# Altium schematic sheet 1.Power.SchDoc(1.Power)
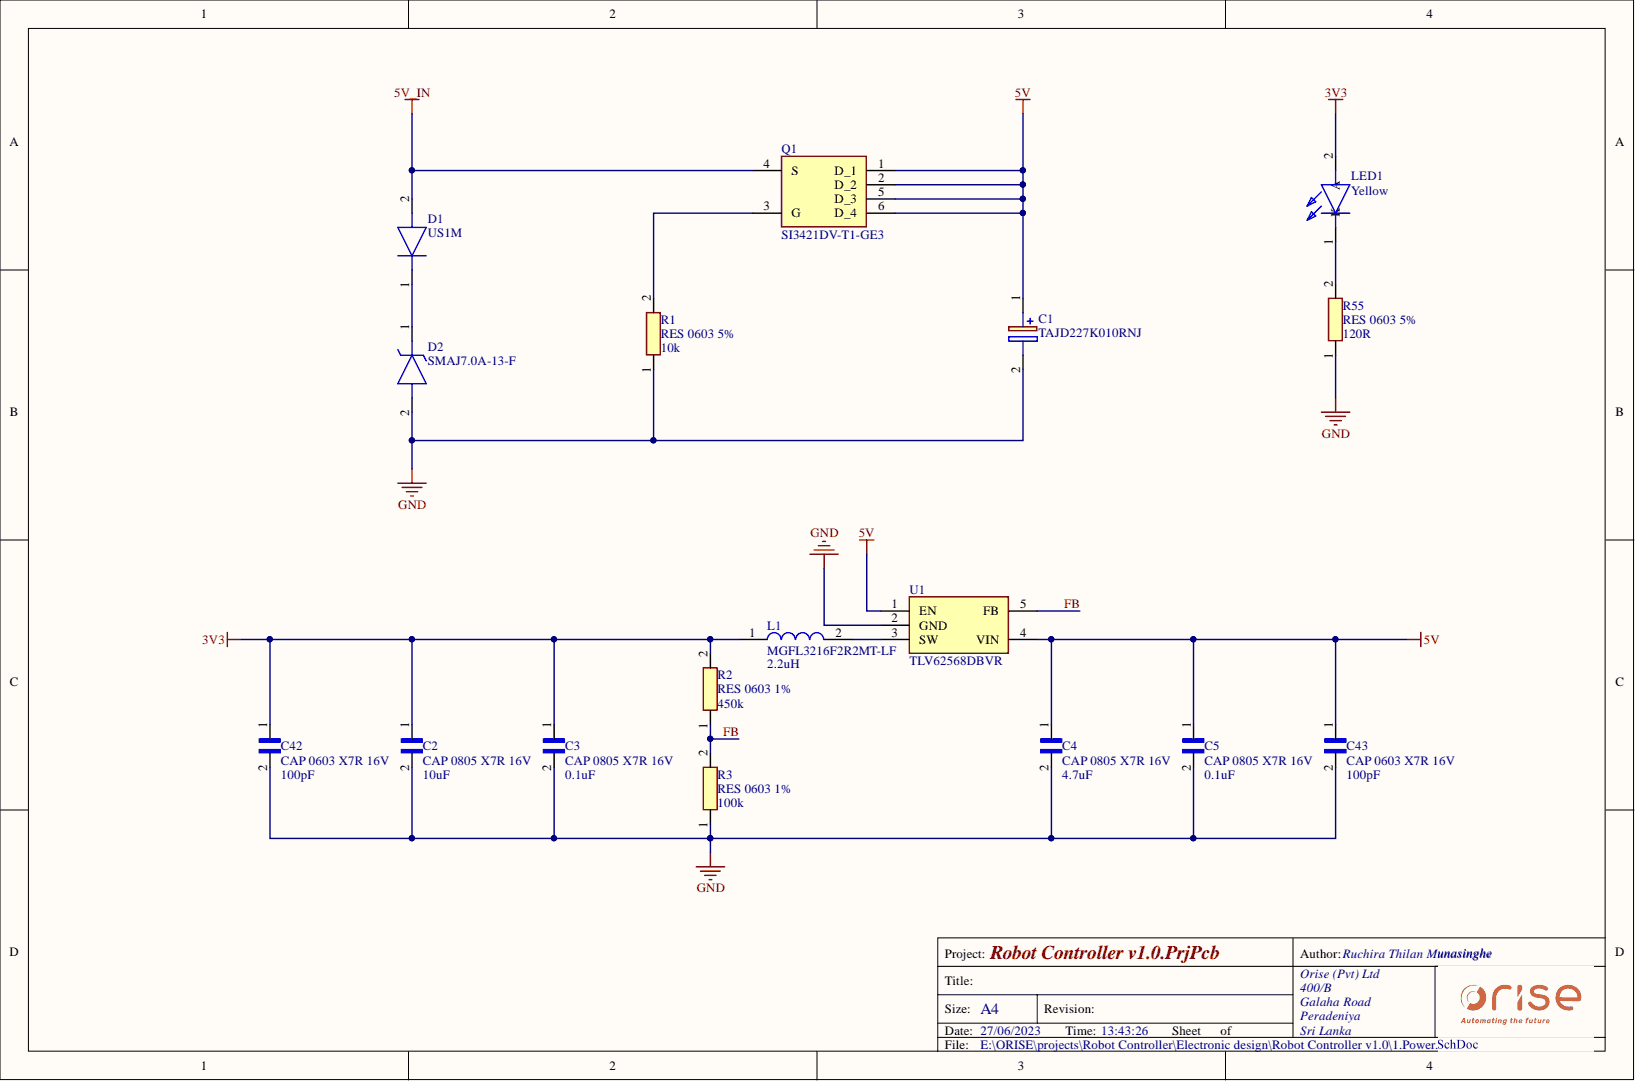
[diagram p0: 1.Power.SchDoc]

## Parts
C1  TAJD227K010RNJ  [TAJD227K010RNJ]
C2  CAP 0805 X7R 16V  value 10uF  [CAP 0805]
C3  CAP 0805 X7R 16V  value 0.1uF  [CAP 0805]
C4  CAP 0805 X7R 16V  value 4.7uF  [CAP 0805]
C5  CAP 0805 X7R 16V  value 0.1uF  [CAP 0805]
C42  CAP 0603 X7R 16V  value 100pF  [CAP 0603]
C43  CAP 0603 X7R 16V  value 100pF  [CAP 0603]
D1  US1M  [US1M]
D2  SMAJ7.0A-13-F  [SMAJ7.0A-13-F]
L1  MGFL3216F2R2MT-LF  value 2.2uH  [MGFL3216F2R2MT-LF]
LED1  color: Yellow  [LED0603]  (hidden comment: led 0603)
Q1  SI3421DV-T1-GE3  [SI3421DV-T1-GE3]
R1  RES 0603 5%  value 10k  [RES 0603]
R2  RES 0603 1%  value 450k  [RES 0603]
R3  RES 0603 1%  value 100k  [RES 0603]
R55  RES 0603 5%  value 120R  [RES 0603]
U1  TLV62568DBVR  [TLV62568DBVR]

## Nets
3V3: C2.1 C3.1 C42.1 L1.1 LED1.2 R2.2
5V: C1.1 C4.1 C5.1 C43.1 Q1.1(D_1) Q1.2(D_2) Q1.5(D_3) Q1.6(D_4) U1.1(EN) U1.4(VIN)
5V_IN: D1.2 Q1.4(S)
GND: C1.2 C2.2 C3.2 C4.2 C5.2 C42.2 C43.2 D2.2 R1.1 R3.1 R55.1 U1.2(GND)
FB: R2.1 R3.2 U1.5(FB)
NetD1_1: D1.1 D2.1
NetL1_2: L1.2 U1.3(SW)
NetLED1_1: LED1.1 R55.2
NetQ1_3: Q1.3(G) R1.2

## Library data of the parts
C1 = TAJD227K010RNJ: 220uF 10V -55℃~+125℃ 500mΩ@100kHz ±10% CASE-D_7343  Tantalum Capacitors ROHS; Manufacturer_Name: Kyocera AVX; Manufacturer_Part_Number: TAJD227K010RNJ; Library Name: Robot Controller v1.0.SchLib; LCSC Part Number: C8024; Component Kind: Standard
D1 = US1M: 1.7V@1A 5uA@1kV 75ns Single 1A -50℃~+150℃@(Tj) 1kV SMA  Diodes - Fast Recovery Rectifiers ROHS; Manufacturer_Name: MDD（Microdiode Electronics）; Manufacturer_Part_Number: US1M; Library Name: Robot Controller v1.0.SchLib; LCSC Part Number: C412437; Component Kind: Standard
D2 = SMAJ7.0A-13-F: 33.3A 12V 7.78V 7V Unidirectional SMA(DO-214AC)  TVS ROHS; Manufacturer_Name: Diodes Incorporated; Manufacturer_Part_Number: SMAJ7.0A-13-F; Library Name: Robot Controller v1.0.SchLib; LCSC Part Number: C135006; Component Kind: Standard
L1 = MGFL3216F2R2MT-LF: 1.3A 2.2uH ±20% 150mΩ 1206 Inductors (SMD) ROHS; Manufacturer_Name: microgate; Manufacturer_Part_Number: MGFL3216F2R2MT-LF; Library Name: Robot Controller v1.0.SchLib; LCSC Part Number: C486337; Component Kind: Standard
Q1 = SI3421DV-T1-GE3: P-Channel 30V 8A (Tc) 2W (Ta), 4.2W (Tc) Surface Mount 6-TSOP; Manufacturer: Vishay Siliconix; Manufacturer Part Number: SI3421DV-T1-GE3; Library Name: Robot Controller v1.0.SchLib; LCSC Part Number: C144884; Component Kind: Standard
U1 = TLV62568DBVR: 5.5V 1A SOT-23-5 DC-DC Converters RoHS; Manufacturer_Name: Texas Instruments; Manufacturer_Part_Number: TLV62568DBVR; Library Name: Robot Controller v1.0.SchLib; LCSC Part Number: C163219; Component Kind: Standard
C2-C5 = CAP 0805 X7R 16V: Library Name: Robot Controller v1.0.SchLib; Description: CAP 0805 X7R 16V; Component Kind: Standard
C42 C43 = CAP 0603 X7R 16V: Library Name: Robot Controller v1.0.SchLib; Description: CAP 0603 X7R 16V; Component Kind: Standard
LED1 = led 0603: Library Name: Robot Controller v1.0.SchLib; Description: Led 0603; Component Kind: Standard
R1 R55 = RES 0603 5%: Library Name: Robot Controller v1.0.SchLib; Description: RES 0603 5%; Component Kind: Standard
R2 R3 = RES 0603 1%: Library Name: Robot Controller v1.0.SchLib; Description: RES 0603 1%; Component Kind: Standard
